# Altium schematic sheet Power_Supply.SchDoc
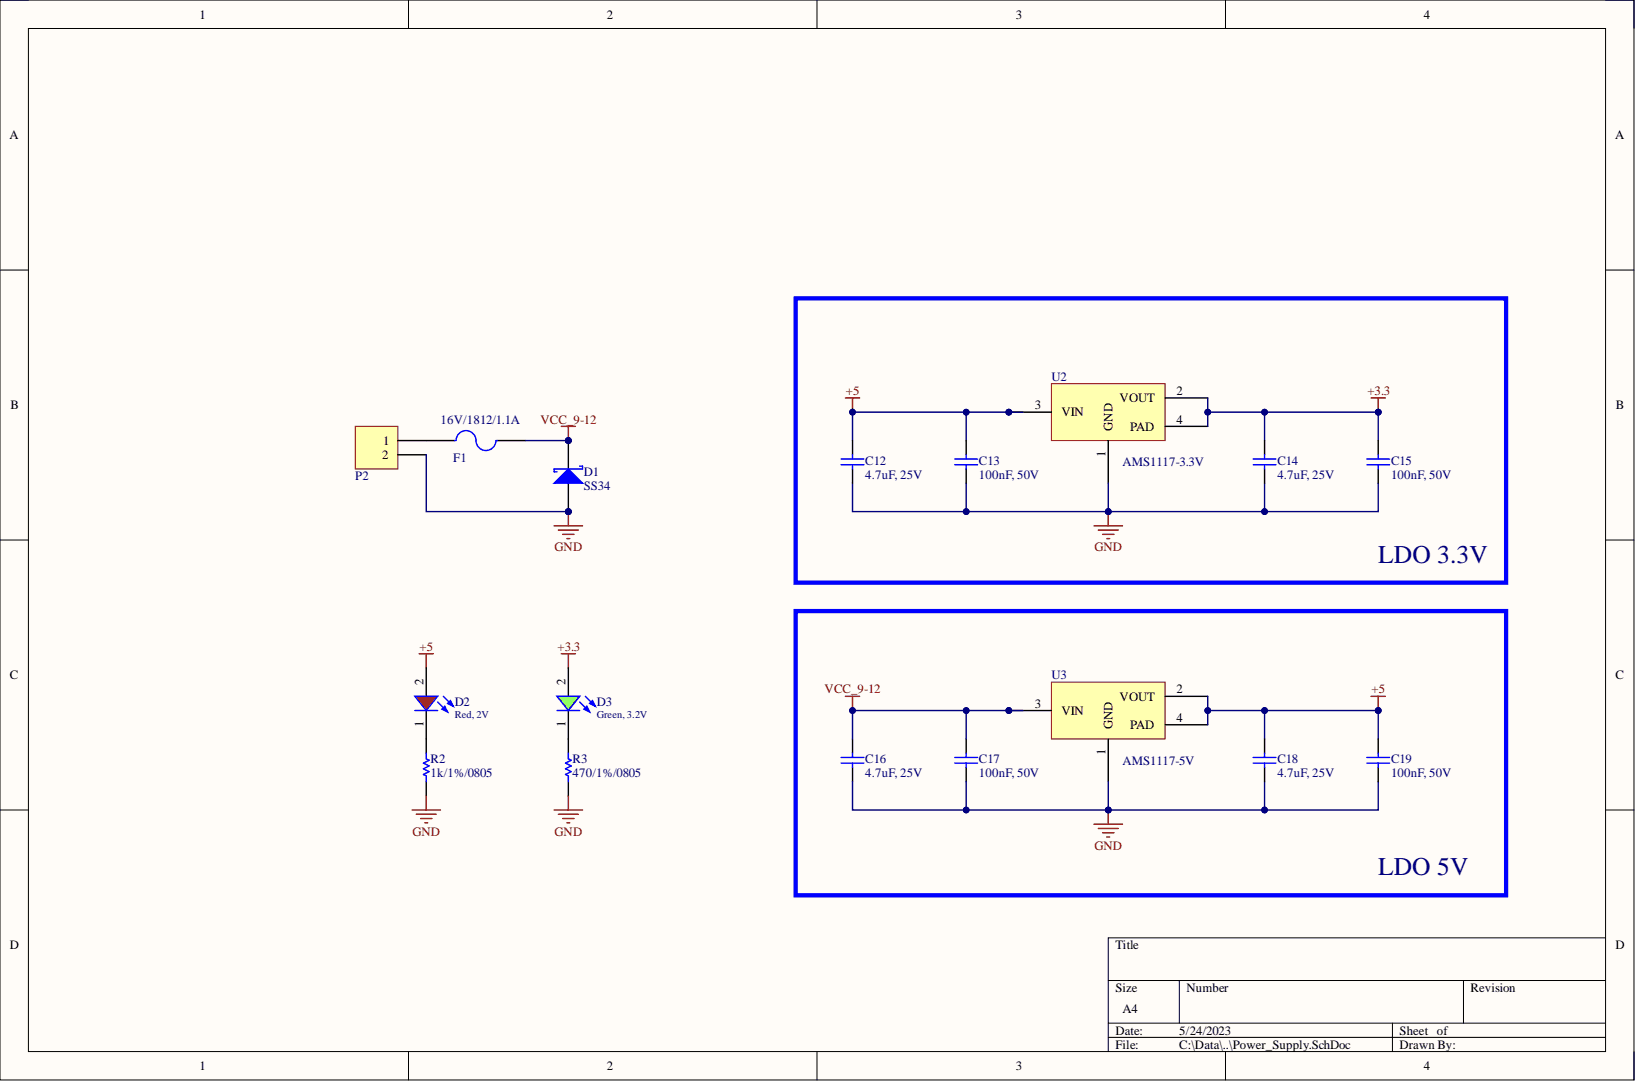
[diagram p0: Power_Supply.SchDoc]

## Parts
C12  4.7uF, 25V  [WCAP-CSGP_0805_H=1.25mm]
C13  100nF, 50V  [WCAP-CSGP_0805_H=0.8mm_Wave]
C14  4.7uF, 25V  [WCAP-CSGP_0805_H=1.25mm]
C15  100nF, 50V  [WCAP-CSGP_0805_H=0.8mm_Wave]
C16  4.7uF, 25V  [WCAP-CSGP_0805_H=1.25mm]
C17  100nF, 50V  [WCAP-CSGP_0805_H=0.8mm_Wave]
C18  4.7uF, 25V  [WCAP-CSGP_0805_H=1.25mm]
C19  100nF, 50V  [WCAP-CSGP_0805_H=0.8mm_Wave]
D1  D Schottky  [SS34]  (value not drawn)
D2  Red, 2V  Forward Voltage typ.: 2V  [WL-SMCW_0805]
D3  Green, 3.2V  [WL-SMCW_0805]
F1  Fuse  [FUSE SMD]  (value not drawn)
P2  Domino 2  [DOMINO 2- 5mm]  (value not drawn)
R2  value 1k/1%/0805  [RES-SM-0805]  (hidden comment: RESISTOR SMD)
R3  value 470/1%/0805  [RES-SM-0805]  (hidden comment: RESISTOR SMD)
U2  AMS1117-3.3V  [SOT230P700X180-4N]
U3  AMS1117-5V  [SOT230P700X180-4N]

## Nets
+3.3: C14.2 C15.2 D3.2 U2.2(VOUT) U2.4(PAD)
+5: C12.2 C13.2 C18.2 C19.2 D2.2 U2.3(VIN) U3.2(VOUT) U3.4(PAD)
GND: C12.1 C13.1 C14.1 C15.1 C16.1 C17.1 C18.1 C19.1 D1.A P2.2 R2.1 R3.1 U2.1(GND) U3.1(GND)
VCC_9-12: C16.2 C17.2 D1.K F1.2 U3.3(VIN)
NetD2_1: D2.1 R2.2
NetD3_1: D3.1 R3.2
NetF1_1: F1.1 P2.1

## Library data of the parts
C12 C14 C16 C18 = 885012107018: WCAP-CSGP Multilayer Ceramic Chip Capacitor, General Purpose, size 0805, X5R, 4.7uF, 25V; Manufacturer: Wurth Elektronik; Capacitance Tolerance: +/-20%; Dissipation Factor: #NAME?; Isolation Resistance: >=0.02GOhm; Rated Voltage: 25VDC; Technical Reference: X5R0805475M025DFCT10000; Capacitance: 4.7uF; Ceramic Type: X5R; Component Kind: Standard; ComponentLink1Description: Manufacturer; ComponentLink1URL: http://www.we-online.de; ComponentLink2Description: Datasheet; ComponentLink2URL: https://www.we-online.com/catalog/datasheet/885012107018.pdf; Height: 1.25mm; Library Name: Altium_WCAP-CSGP (rev20a).IntLib; Manufacturer Part Number: 885012107018; Mounting Technology: SMT; Operating Temperature: -55 to +85degC; Packaging: Tape and Reel; Published Date: May 2020; Size: 0805
C13 C15 C17 C19 = 885012207098: WCAP-CSGP Multilayer Ceramic Chip Capacitor, General Purpose, size 0805, X7R, 100nF, 50V; Manufacturer: Wurth Elektronik; Capacitance Tolerance: +/-10%; Dissipation Factor: #NAME?; Isolation Resistance: >=5GOhm; Rated Voltage: 50VDC; Technical Reference: X7R0805104K050DFCT10000; Capacitance: 100nF; Ceramic Type: X7R; Component Kind: Standard; ComponentLink1Description: Manufacturer; ComponentLink1URL: http://www.we-online.de; ComponentLink2Description: Datasheet; ComponentLink2URL: https://www.we-online.com/catalog/datasheet/885012207098.pdf; Height: 0.8mm; Library Name: Altium_WCAP-CSGP (rev20a).IntLib; Manufacturer Part Number: 885012207098; Mounting Technology: SMT; Operating Temperature: -55 to +125degC; Packaging: Tape and Reel; Published Date: May 2020; Size: 0805
D1 = D Schottky: Schottky Diode; Code_JEDEC: DO-214-AA; Component Kind: Standard; LatestRevisionDate: 17-Jul-2002; LatestRevisionNote: Re-released for DXP Platform.; Library Name: PIF_Library.IntLib; PackageDescription: DO-214-AA/SMB; 2 C-Bend Leads; Body 5.3 x 3.6 mm, inc. leads (LxW); PackageReference: SMB; Published: 8-Jun-2000; Supplier 1: Arrow Electronics; Supplier 2: RSComponents; Supplier Part Number 1: SS34; Supplier Part Number 2: 8080433; Publisher: Altium Limited
D2 = 150080RS75000: WL-SMCW SMT Mono-color Chip LED Waterclear, size 0805, Red, 2V, 140deg; Chip Technology: AlInGaP; Dominant Wavelength typ.: 625nm; Luminous Intensity typ.: 150mcd; Peak Wavelength typ.: 630nm; Component Kind: Standard; ComponentLink1Description: Manufacturer; ComponentLink1URL: http://www.we-online.de; ComponentLink2Description: Datasheet; ComponentLink2URL: https://www.we-online.com/catalog/datasheet/150080RS75000.pdf; Emitting Color: Red; Library Name: Altium_WL-SMCW (rev20a).IntLib; Mounting Technology: SMT; Operating Temperature: -40 to +85degC; Packaging: Tape and Reel; Part Number: 150080RS75000; Published Date: December 2019; Size: 0805; Viewing Angle: 140deg; Publisher: Wurth Elektronik
D3 = 150080GS75000: WL-SMCW SMT Mono-color Chip LED Waterclear, size 0805, Green, 3.2V, 140deg; Chip Technology: InGaN; Dominant Wavelength typ.: 525nm; Luminous Intensity typ.: 450mcd; Peak Wavelength typ.: 515nm; Component Kind: Standard; ComponentLink1Description: Manufacturer; ComponentLink1URL: http://www.we-online.de; ComponentLink2Description: Datasheet; ComponentLink2URL: https://www.we-online.com/catalog/datasheet/150080GS75000.pdf; Emitting Color: Green; Forward Voltage typ.: 3.2V; Library Name: Altium_WL-SMCW (rev20a).IntLib; Mounting Technology: SMT; Operating Temperature: -40 to +85degC; Packaging: Tape and Reel; Part Number: 150080GS75000; Published Date: December 2019; Size: 0805; Viewing Angle: 140deg; Publisher: Wurth Elektronik
P2 = Domino 2: Domino, 2-Pin; Component Kind: Standard; LatestRevisionDate: 17-Jul-2002; LatestRevisionNote: Re-released for DXP Platform.; Library Name: PIF_Library.IntLib; Publisher: Altium Limited
R2 R3 = RESISTOR SMD: Resistor; Component Kind: Standard; Library Name: PIF_Library.IntLib
F1 = Fuse: Component Kind: Standard; Description: Fuse; Library Name: PIF_Library.IntLib
U2 = AMS1117-3.3V: Component Kind: Standard; Library Name: MiclsLib.IntLib
U3 = AMS1117-5V: Component Kind: Standard; Library Name: MiclsLib.IntLib
